# Altium schematic sheet SRPeripherals.SchDoc(SRPeripherals)
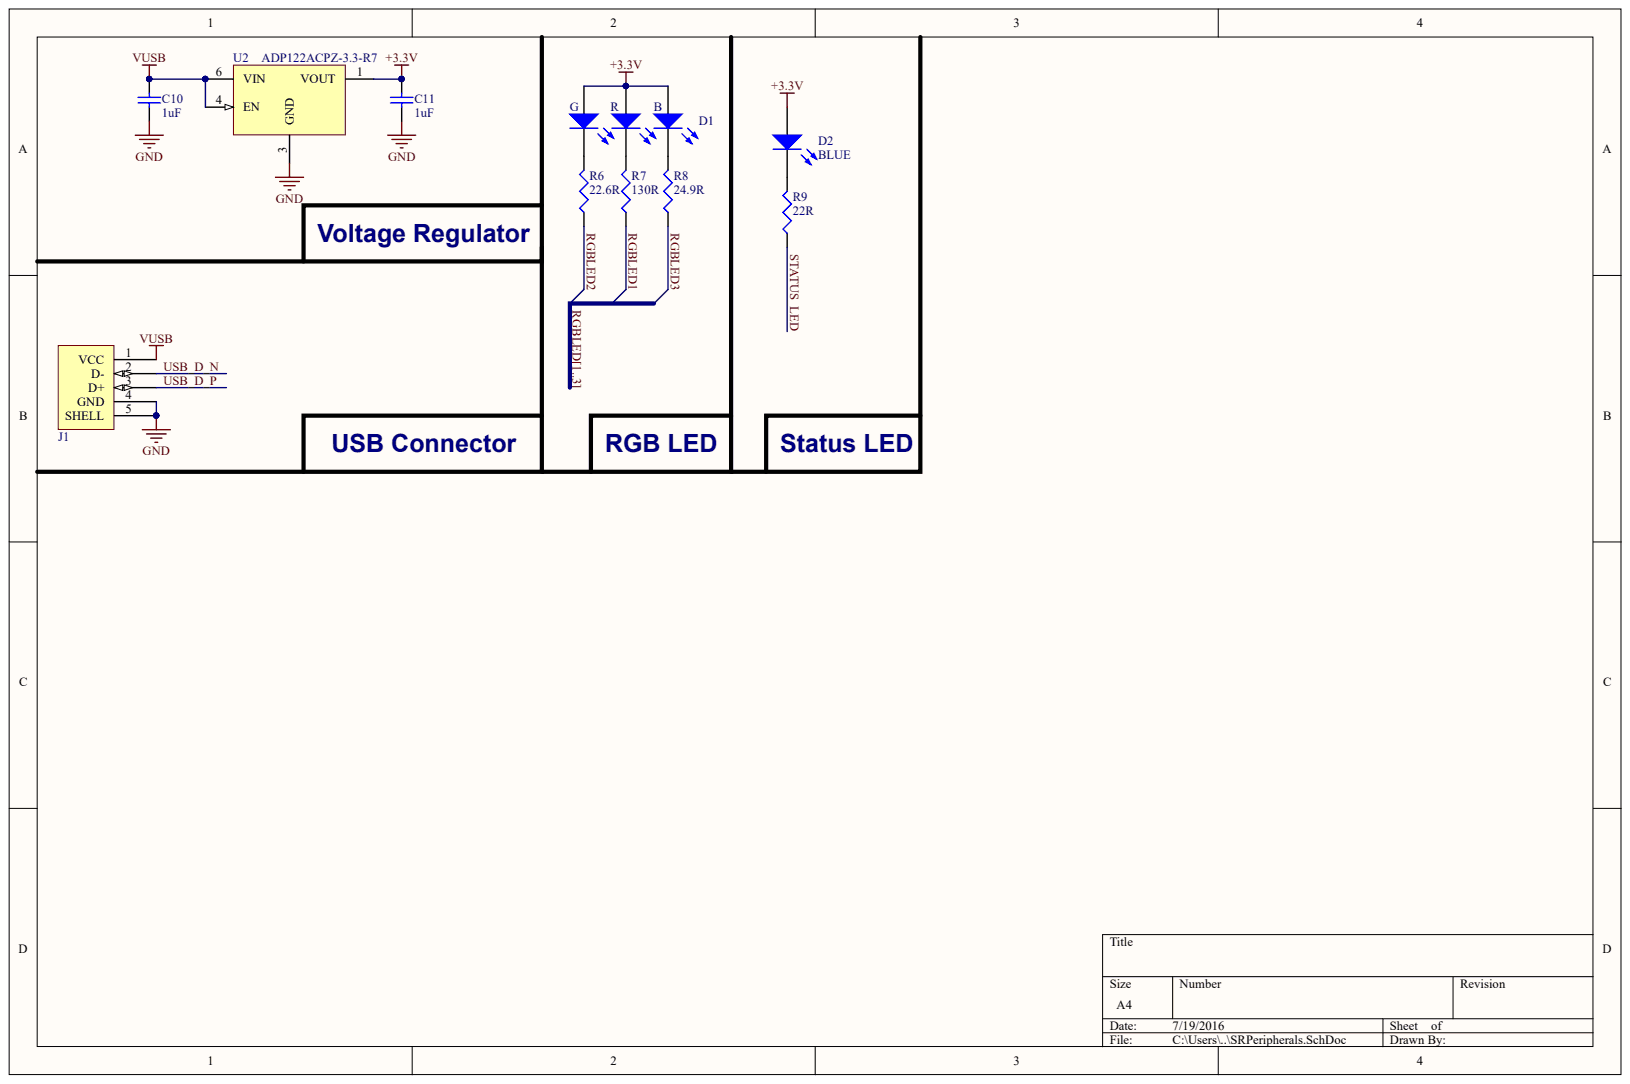
[diagram p0: SRPeripherals.SchDoc]

## Parts
C10  1uF  [0603]
C11  1uF  [0603]
D1  SMLVN6RGB1W1  [3.5x2.8 mm 6-SMD]  (value not drawn)
D2  value BLUE  [0603 - LED]  (hidden comment: BLUE LED)
J1  48037-2200  [48037-2200]  (value not drawn)
R6  22.6R  [0402]
R7  130R  [0402]
R8  24.9R  [0402]
R9  22R  [0402]
U2  ADP122ACPZ-3.3-R7  [LFCSP-6 2.0X2.0X0.55]

## Nets
+3.3V: C11.2 D1.1 D1.2 D1.3 D2.1 U2.1(VOUT)
GND: C10.1 C11.1 J1.4(GND) J1.5(SHELL) U2.2 U2.3(GND) U2.5 U2.7
VUSB: C10.2 J1.1(VCC) U2.4(EN) U2.6(VIN)
RGBLED1: R7.1  [also labelled RGBLED]
RGBLED2: R6.1  [also labelled RGBLED]
RGBLED3: R8.1  [also labelled RGBLED]
STATUS_LED: R9.1
USB_D_N: J1.2(D-)
USB_D_P: J1.3(D+)
NetD1_4: D1.4 R6.2
NetD1_5: D1.5 R7.2
NetD1_6: D1.6 R8.2
NetD2_2: D2.2 R9.2

## Library data of the parts
C10 C11 = 1uF: 1uF 0603 X5R 10V ±10%; Component Kind: Standard; Library Name: SBComponentsSchematics.SchLib; Supplier 1: Farnell; Supplier 2: Digi-Key; Supplier Part Number 1: 1759399; Supplier Part Number 2: 478-1251-1-ND; Value: 1uF
D1 = SMLVN6RGB1W1: ROHM SMLVN6RGB1W1 LED Tri-Color Blue/Green/Red Chip LED 6-Pin 1411 T/RLED Tri-Color Blue/Green/Red Chip LED 6-Pin 1411; Component Kind: Standard; Library Name: SBComponentsSchematics.SchLib; Supplier 1: Mouser; Supplier 2: Digi-Key; Supplier Part Number 1: 755-SMLVN6RGB1W1; Supplier Part Number 2: 846-1187-1-ND
D2 = BLUE LED: BRIGHT BLUE LED 20mA; Component Kind: Standard; Library Name: SBComponentsSchematics.SchLib; Supplier 1: Farnell; Supplier 2: Digi-Key; Supplier Part Number 1: 1716770; Supplier Part Number 2: 160-1646-1-ND
J1 = 48037-2200: Molex USB 2.0 Connector Plug USB Type A; Component Kind: Standard; Library Name: SBComponentsSchematics.SchLib; Supplier 1: Farnell; Supplier 2: Digi-Key; Supplier Part Number 1: 2313729; Supplier Part Number 2: WM3983CT-ND
R6 = 22.6R: 22.6R 0402 1/16W ±0.05R; Component Kind: Standard; Library Name: SBComponentsSchematics.SchLib; Supplier 1: Farnell; Supplier 2: Digi-Key; Supplier Part Number 1: 2302454; Supplier Part Number 2: P22.6LCT-ND; Value: 22.6R
R7 = 130R: 130R 0402 1/16W ±0.05R; Component Kind: Standard; Library Name: SBComponentsSchematics.SchLib; Supplier 1: Farnell; Supplier 2: Digi-Key; Supplier Part Number 1: 2059115; Supplier Part Number 2: P130LCT-ND; Value: 130R
R8 = 24.9R: 24.9R 0402 1/16W ±0.05R; Component Kind: Standard; Library Name: SBComponentsSchematics.SchLib; Supplier 1: Farnell; Supplier 2: Digi-Key; Supplier Part Number 1: 2059102; Supplier Part Number 2: P24.9LCT-ND; Value: 24.9R
R9 = 22R: 22R 0402 1/16W ±1%; Component Kind: Standard; Library Name: SBComponentsSchematics.SchLib; Supplier 1: Farnell; Supplier 2: Digi-Key; Supplier Part Number 1: 2072797; Supplier Part Number 2: 541-22.0LCT-ND; Value: 22R
U2 = ADP122ACPZ-3.3-R7: Analog Devices ADP122ACPZ-3.3-R7 - 5.5 V Input, 300 mA, Low Quiescent  Current, CMOS Linear Regulator; Component Kind: Standard; Library Name: SBComponentsSchematics.SchLib; Supplier 1: Farnell; Supplier 2: Digi-Key; Supplier Part Number 1: 2457164; Supplier Part Number 2: ADP122ACPZ-3.3-R7CT-ND
